# Altium schematic sheet TopSheet.SchDoc(TopSheet)
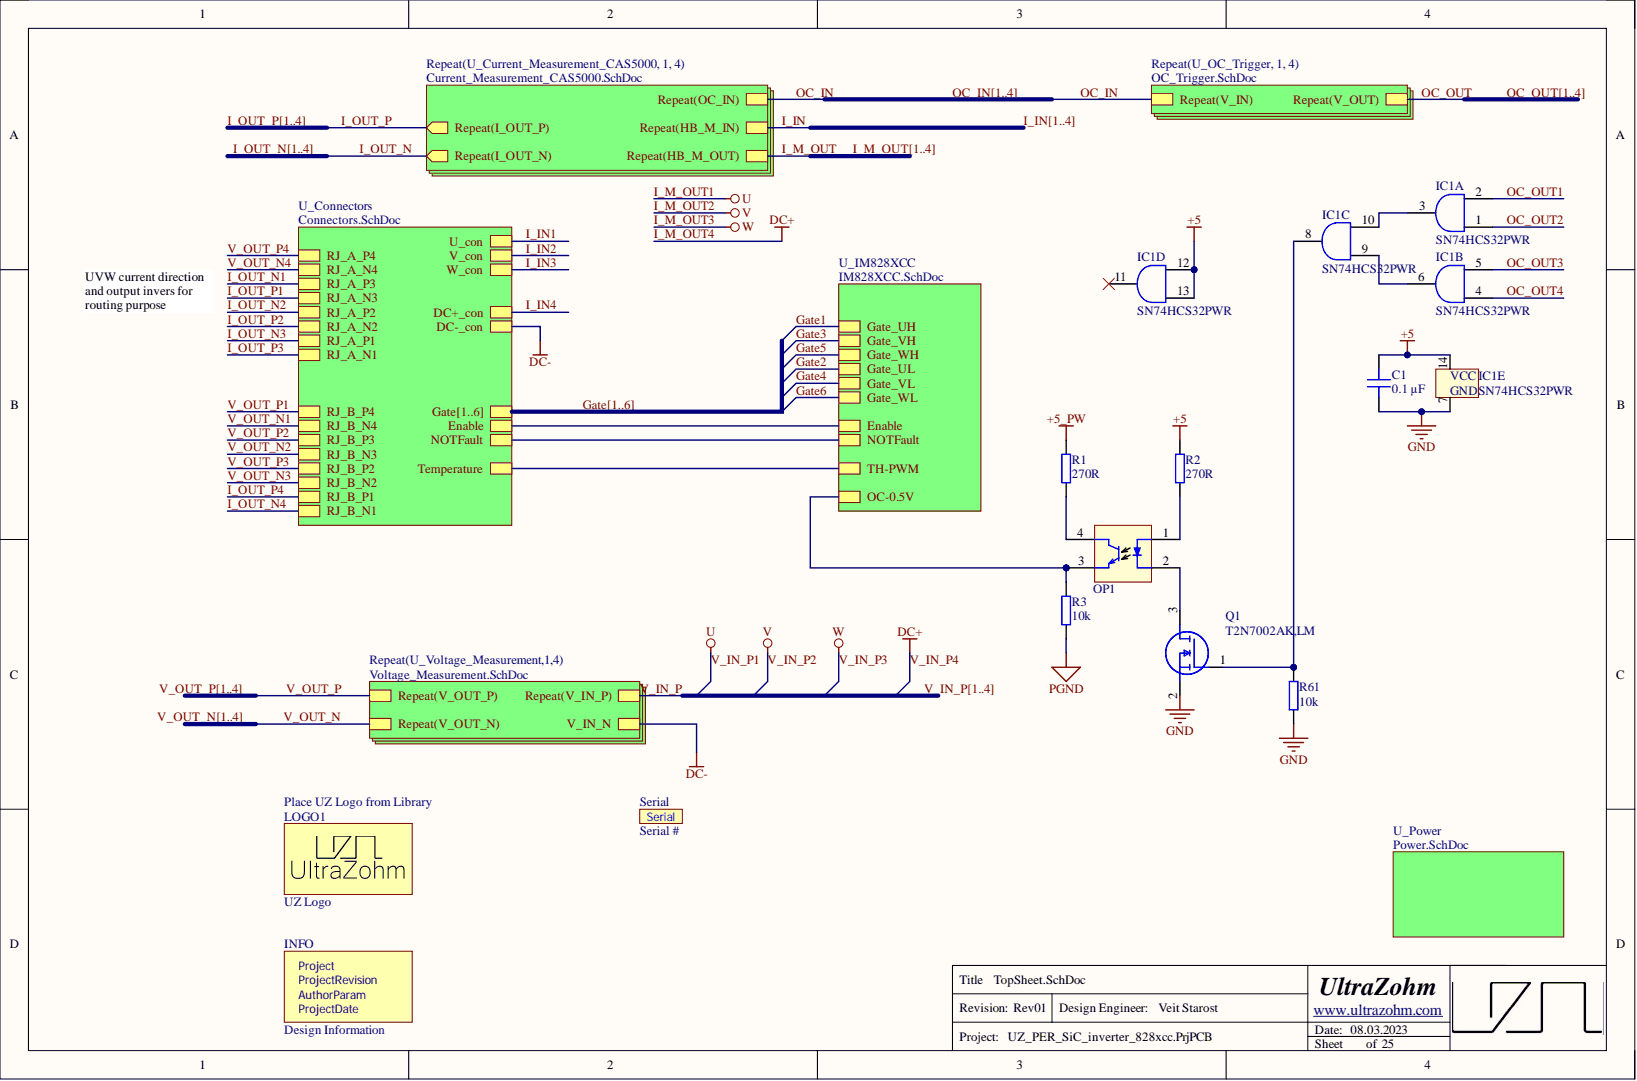
[diagram p0: TopSheet.SchDoc]

## Parts
C1  0.1 µF  [C_0603]
IC1  SN74HCS32PWR  [TSSOP-14]  (parts A,B,C,D,E)
INFO  Design Information  [Design_info]  (no BOM)
LOGO1  UZ Logo  value Logo  Component Type: Logo  [UZ_Logo_small]  (no BOM)
OP1  VO617A-2X017T  [SMD4]  (value not drawn)
Q1  T2N7002AK,LM  [SOT-23-3]
R1  270R  [RES 0603_1608]
R2  270R  [RES 0603_1608]
R3  10k  [RES 0603_1608]
R61  10k  [RES 0603_1608]
Serial  Serial #  [SERIAL]  (no BOM)

## Nets
+5: C1.2 IC1.12 IC1.13 IC1.14 R2.2
+5_PW: R1.2
GND: C1.1 IC1.7 Q1.2 R61.1
PGND: R3.1
OC_OUT1: IC1.2  [also labelled OC_OUT]
OC_OUT2: IC1.1  [also labelled OC_OUT]
OC_OUT3: IC1.5  [also labelled OC_OUT]
OC_OUT4: IC1.4  [also labelled OC_OUT]
NetIC1_3: IC1.3 IC1.10
NetIC1_6: IC1.6 IC1.9
NetIC1_8: IC1.8 Q1.1 R61.2
NetIC1_11: IC1.11
NetOP1_1: OP1.1 R2.1
NetOP1_2: OP1.2 Q1.3
NetOP1_3: OP1.3 R3.2
NetOP1_4: OP1.4 R1.1
DC-:
Enable:
Gate1:  [also labelled Gate]
Gate2:  [also labelled Gate]
Gate3:  [also labelled Gate]
Gate4:  [also labelled Gate]
Gate5:  [also labelled Gate]
Gate6:  [also labelled Gate]
I_IN1:  [also labelled I_IN]
I_IN2:  [also labelled I_IN]
I_IN3:  [also labelled I_IN]
I_IN4:  [also labelled I_IN]
I_M_OUT1:  [also labelled I_M_OUT]
I_M_OUT2:  [also labelled I_M_OUT]
I_M_OUT3:  [also labelled I_M_OUT]
I_M_OUT4:  [also labelled I_M_OUT]
I_OUT_N1:  [also labelled I_OUT_N]
I_OUT_N2:  [also labelled I_OUT_N]
I_OUT_N3:  [also labelled I_OUT_N]
I_OUT_N4:  [also labelled I_OUT_N]
I_OUT_P1:  [also labelled I_OUT_P]
I_OUT_P2:  [also labelled I_OUT_P]
I_OUT_P3:  [also labelled I_OUT_P]
I_OUT_P4:  [also labelled I_OUT_P]
NOTFault:
OC_IN1:  [also labelled OC_IN]
OC_IN2:  [also labelled OC_IN]
OC_IN3:  [also labelled OC_IN]
OC_IN4:  [also labelled OC_IN]
Temperature:
V_OUT_N1:  [also labelled V_OUT_N]
V_OUT_N2:  [also labelled V_OUT_N]
V_OUT_N3:  [also labelled V_OUT_N]
V_OUT_N4:  [also labelled V_OUT_N]
V_OUT_P1:  [also labelled V_OUT_P]
V_OUT_P2:  [also labelled V_OUT_P]
V_OUT_P3:  [also labelled V_OUT_P]
V_OUT_P4:  [also labelled V_OUT_P]

## Library data of the parts
C1 = C0603X104K5RAC7411: Capacitor 0603, 50 VDC, 0.1 µF, X7R; Manufacturer: KEMET; Created from: MGremer; Database Table Name: Capacitors - SMD; Tolerance: 10 %; Voltage Rating: 50 VDC; Component Kind: Standard; Component Type: Capacitor 0603; ComponentLink1Description: Datasheet; ComponentLink1URL: https://www.mouser.de/datasheet/2/212/1/KEM_C1013_X7R_FT_CAP_SMD-1103280.pdf; Created On: 20.12.2020 13:54:13; Device Package: 0603; Last Updated: 04.03.2021 09:24:28; LCSC Part Number: C14663; Library Name: user.DbLib; Live Cycle Status: 1; Manufacturer Part Number: C0603X104K5RAC7411; Mounting Type: SMD; Operating Temperature: -55 - 125°C; PartID: 3; Series: SMD Comm X7R Flex; Stock number: Labor SMD Container; Supplier 1: Mouser; Supplier 2: LCSC; Supplier Part Number 1: 80-C0603X104K5R7411; Supplier Part Number 2: C14663; Type: Capacitor; Value: 0.1 µF
IC1 = SN74HCS32PWR: Logische Gatter Quadruple 2-input OR gates with Schmitt-trigger inputs 14-TSSOP -40 to 125; Manufacturer: Texas Instruments; Created from: VStarost; Database Table Name: Logic - Gates and Inverters; Value: 6 V; Component Kind: Standard; Component Type: OR; ComponentLink1Description: Datasheet; ComponentLink1URL: https://www.ti.com/lit/ds/symlink/sn74hcs32.pdf?HQS=dis-mous-null-mousermode-dsf-pf-null-wwe&ts=1675161071566&ref_url=https%253A%252F%252Fwww.mouser.de%252F; Created On: 31.01.2023 11:53:14; Device Package: TSSOP-14; Last Updated: 31.01.2023 11:53:14; Library Name: user.DbLib; Live Cycle Status: 1; Manufacturer Part Number: SN74HCS32PWR; Mounting Type: SMD; Operating Temperature: -40 - 125 °C; PartID: 5; Series: SN74HCS32; Stock number: not stored; Supplier 1: Mouser; Supplier Part Number 1: 595-SN74HCS32PWR; Type: OR
INFO = Design Information: Manufacturer: UltraZohm; Created from: MGremer; Database Table Name: Misc; Component Type: Design Information; ComponentLink1Description: https://docs.ultrazohm.com/; ComponentLink1URL: homepage; Created On: 03.11.2021 09:04:23; Description: Design Information; Last Updated: 03.11.2021 09:28:34; Library Name: user.DbLib; Live Cycle Status: 1; Manufacturer Part Number: Design Information; PartID: 12; Supplier 1: -; Supplier Part Number 1: -; Type: Design Information; Value: Design Information
LOGO1 = Logo small: Manufacturer: UltraZohm; Created from: MGremer; Database Table Name: Misc; ComponentLink1Description: https://docs.ultrazohm.com/; ComponentLink1URL: homepage; Created On: 03.11.2021 09:04:23; Description: UZ Logo; Last Updated: 03.11.2021 09:05:50; Library Name: user.DbLib; Live Cycle Status: 1; Manufacturer Part Number: Logo small; PartID: 10; Supplier 1: -; Supplier Part Number 1: -; Type: Logo; Created: Jan2021_Liegmann
OP1 = VO617A-2X017T: Transistor-Ausgangsoptokoppler H. Relblty 5300 Vrms 63-125% CTR, VDE; Manufacturer: Vishay; Channels: 1; Created from: VStarost; Database Table Name: Logic - Isolators; Component Kind: Standard; Component Type: Optokoppler; ComponentLink1Description: Datasheet; ComponentLink1URL: https://www.mouser.de/datasheet/2/427/vo617a-1766974.pdf; Created On: 31.01.2023 09:27:11; Last Updated: 31.01.2023 09:27:11; Library Name: user.DbLib; Live Cycle Status: 1; Manufacturer Part Number: VO617A-2X017T; Mounting Type: SMD; Operating Temperature: -55 - +110 °C; PartID: 4; Supplier 1: Mouser; Supplier Part Number 1: 78-VO617A-2X017T; Type: Optokoppler
Q1 = T2N7002AK,LM: Small-signal MOSFET, n-Channel, 200 mA, 60 V; Manufacturer: Toshiba; Created from: VStarost; Database Table Name: MOSFET - N-Channel; Type: Power MOSFET; Value: 60 V; Component Kind: Standard; Component Type: Small-signal MOSFET; ComponentLink1Description: Datasheet; ComponentLink1URL: https://toshiba.semicon-storage.com/info/T2N7002AK_datasheet_en_20150401.pdf?did=29712&prodName=T2N7002AK; Created On: 24.01.2023 08:00:45; Device Package: SOT-23-3; Last Updated: 15.02.2023 18:16:19; LCSC Part Number: C516175; Library Name: user.DbLib; Live Cycle Status: 1; Manufacturer Part Number: T2N7002AK,LM; Mounting Type: SMD; Operating Temperature: -55 - 150 °C; PartID: 4; Series: T2N7002; Stock number: not stored; Supplier 1: Mouser; Supplier 2: Digi-Key; Supplier Part Number 1: 757-T2N7002AKLM; Supplier Part Number 2: T2N7002AKLMCT-ND
R1 R2 = RK73B1JTTDD271J: SMD resistor E24 series; Manufacturer: KOA Speer; Created from: MGremer; Database Table Name: Resistors - SMD; Tolerance: 5%; Component Kind: Standard; Component Type: SMD resistor; ComponentLink1Description: Datasheet; ComponentLink1URL: https://www.mouser.de/datasheet/2/219/RK73B-1825463.pdf; Created On: 14.12.2020 16:17:14; Device Package: 0603; Last Updated: 15.02.2023 17:43:45; LCSC Part Number: C177305; Library Name: user.DbLib; Live Cycle Status: 1; Manufacturer Part Number: RK73B1JTTDD271J; Mounting Type: SMD; PartID: 60; Stock number: Labor SMD Container; Supplier 1: Mouser; Supplier Part Number 1: 660-RK73B1JTTDD271J; Type: Resistor; Value: 270R
R3 R61 = MCWR06X1002FTL: SMD resistor 1%; Manufacturer: Multicomp PRO; Created from: MGremer; Database Table Name: Resistors - SMD; Component Kind: Standard; Component Type: SMD resistor; ComponentLink1Description: Datasheet; ComponentLink1URL: http://www.farnell.com/datasheets/2860681.pdf; Created On: 14.12.2020 16:17:14; Device Package: 0603; Last Updated: 15.02.2023 17:53:17; LCSC Part Number: C269701; Library Name: user.DbLib; Live Cycle Status: 1; Manufacturer Part Number: MCWR06X1002FTL; Mounting Type: SMD; PartID: 176; Stock number: not stored; Supplier 1: Farnell; Supplier Part Number 1: 2447230; Tolerance: 1%; Type: Resistor; Value: 10k
Serial = SerialNumber: Empty serial number of the PCB. Can be used for manually writing the serial number; Library Name: annotation.IntLib
